# Altium schematic sheet battery_charger.SchDoc(battery_charger)
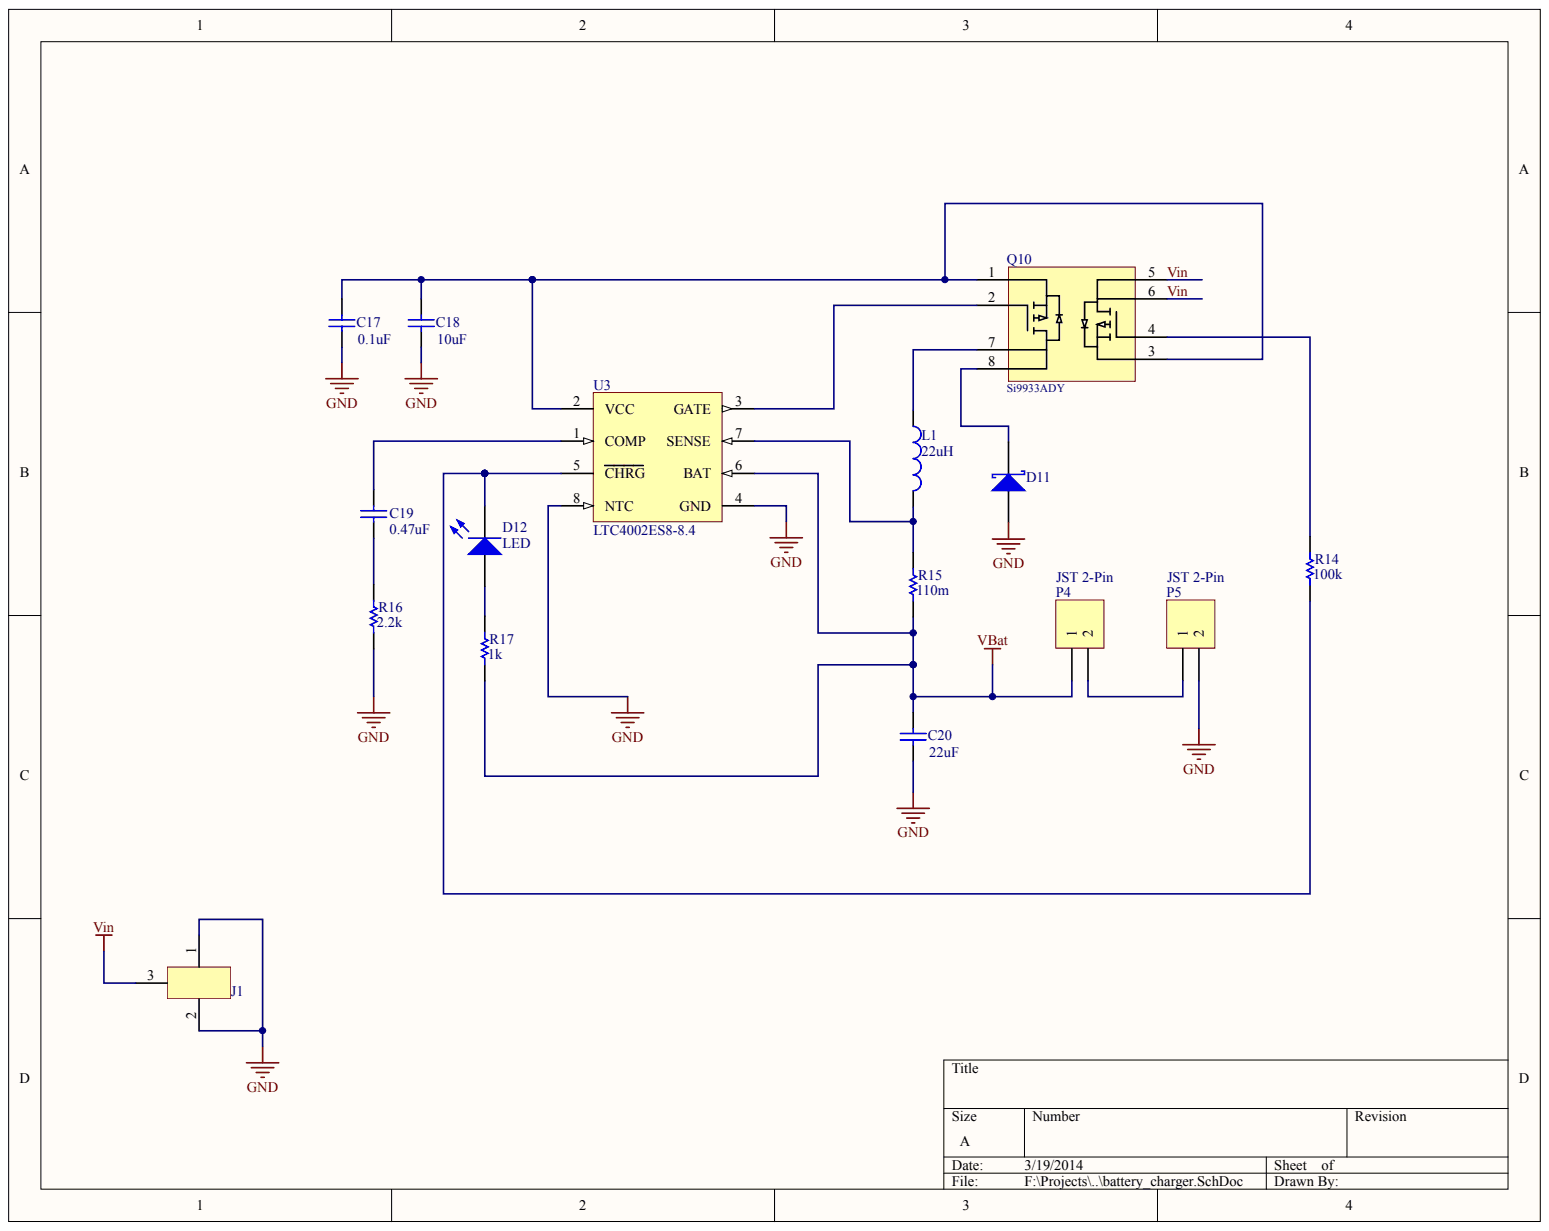
[diagram p0: battery_charger.SchDoc]

## Parts
C17  value 0.1uF  (hidden comment: Cap Semi)
C18  value 10uF  (hidden comment: Cap Semi)
C19  value 0.47uF  (hidden comment: Cap Semi)
C20  value 22uF  (hidden comment: Cap Semi)
D11  D Schottky  (value not drawn)
D12  LED
J1  DC Barrel Jack  (value not drawn)
L1  value 22uH  (hidden comment: Inductor)
P4  JST 2-Pin
P5  JST 2-Pin
Q10  Manufacturer Part N.O.: Si9933ADY  (hidden comment: Dual P-Channel)
R14  value 100k  (hidden comment: Resistor)
R15  value 110m  (hidden comment: Resistor)
R16  value 2.2k  (hidden comment: Resistor)
R17  value 1k  (hidden comment: Resistor)
U3  LTC4002ES8-8.4

## Nets
GND: C17.1 C18.1 C20.1 D11.1 J1.1 J1.2 P5.2 R16.1 U3.4(GND) U3.8(NTC)
VBAT: C20.2 P4.1 R15.1 R17.1 U3.6(BAT)
VIN: J1.3 Q10.5 Q10.6
NetC17_2: C17.2 C18.2 Q10.1 Q10.3 U3.2(VCC)
NetC19_1: C19.1 R16.2
NetC19_2: C19.2 U3.1(COMP)
NetD11_2: D11.2 Q10.8
NetD12_1: D12.1 R17.2
NetD12_2: D12.2 R14.1 U3.5(CHRG)
NetL1_1: L1.1 Q10.7
NetL1_2: L1.2 R15.2 U3.7(SENSE)
NetP4_2: P4.2 P5.1
NetQ10_2: Q10.2 U3.3(GATE)
NetQ10_4: Q10.4 R14.2

## Library data of the parts
D12 = (no symbol name): Vendor Part Number: 751-1203-ND; Manufacturer: Vishay; Manufacturer Part Number: TSAL6100; Vendor: Digikey; Published: 16-Mar-2013; LatestRevisionDate: 16-Mar-2013; LatestRevisionNote: Created; PackageReference: LED; PackageDescription: 5mm LED; 2 Leads; Publisher: Anthony Merlino
L1 = (no symbol name): Code_IEC: 1005; Published: 8-Jun-2000; LatestRevisionDate: 29-May-2009; LatestRevisionNote: IPC-7351 Footprint Added.; PackageReference: 0402-A; PackageDescription: Chip Inductor; Code_JEITA: 0402; Publisher: Altium Limited
U3 = (no symbol name): Manufacturer: Linear Technology; Battery Chemistry: Li-Ion, Li-Polymer; Charge Termination: On-board timer; Float Voltage: 8.4 V; Number of Cells: 2; Type of Charger: Switching; Vin Max(V): 22; Vin Min(V): 8.9; PackageVersion: Rev. G, 03/2012; PackageReference: S8-8; PackageDescription: 8-Pin Plastic Small Outline (Narrow .150 Inch), Body 4.9 x 3.9 mm, Pitch 1.27 mm; Supplier 1: Digi-Key; Supplier Part Number 1: LTC4002ES8-8.4-ND; RoHS: FALSE; Mounting Technology: Surface Mount; PartNumber: LTC4002ES8-8.4; ComponentLink1Description: Manufacturer URL; ComponentLink1URL: http://www.linear.com/; ComponentLink2Description: Datasheet; ComponentLink2URL: http://cds.linear.com/docs/en/datasheet/4002f.pdf; ComponentLink3Description: Package Specification; ComponentLink3URL: http://cds.linear.com/docs/en/packaging/05081610_G_SO8.pdf
C17-C20 D11 J1 P4 P5 Q10 R14-R17 = (no symbol name)
  C17-C20: Published: 8-Jun-2000; LatestRevisionDate: 29-May-2009; LatestRevisionNote: IPC-7351 Footprint Added.; PackageReference: C1206; PackageDescription: Chip Capacitor
  D11: Published: 8-Jun-2000; LatestRevisionDate: 17-Jul-2002; LatestRevisionNote: Re-released for DXP Platform.; PackageReference: SMB; PackageDescription: DO-214-AA/SMB; 2 C-Bend Leads; Body 5.3 x 3.6 mm, inc. leads (LxW); Code_JEDEC: DO-214-AA
  P4 P5: LatestRevisionDate: 17-Jul-2002; LatestRevisionNote: Re-released for DXP Platform.
  R14-R17: Published: 8-Jun-2000; LatestRevisionDate: 17-Jul-2002; LatestRevisionNote: Re-released for DXP Platform.; PackageReference: AXIAL-0.3
  C17-C20 D11 P4 P5 R14-R17: Publisher: Altium Limited
